# Altium schematic sheet CPU_.SchDoc
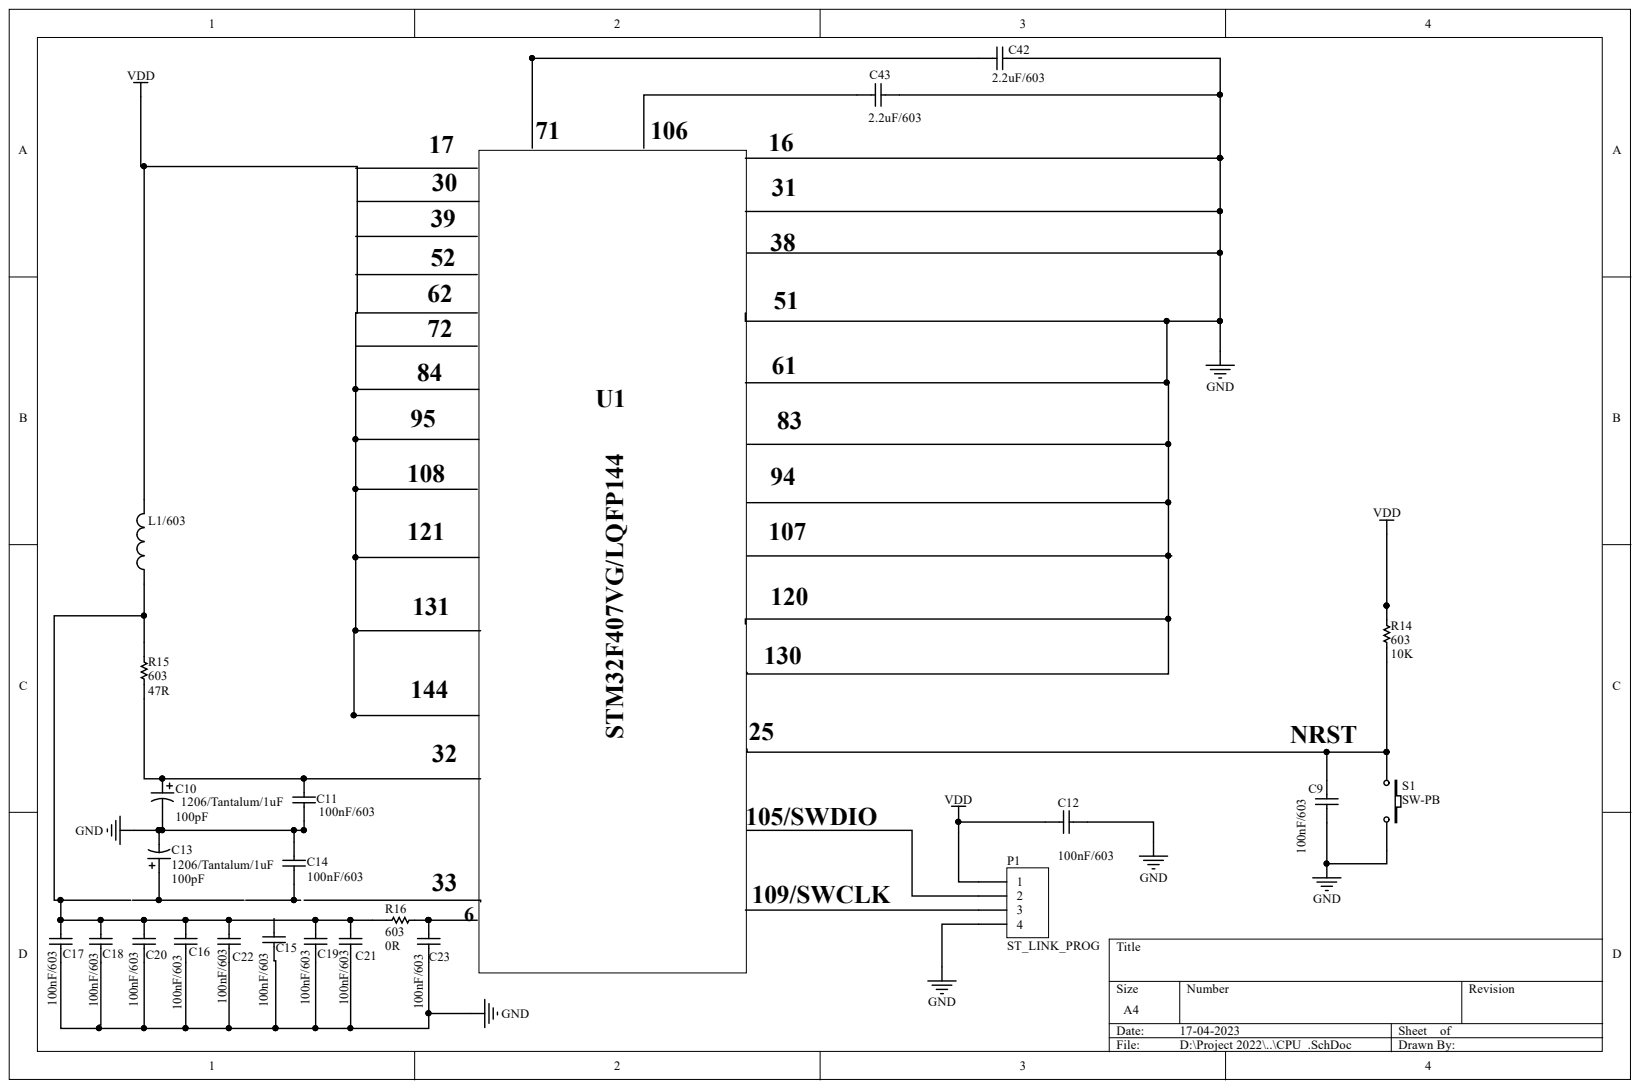
[diagram p0: CPU_.SchDoc]

## Parts
C9  value 100nF/603  [RAD-0.3]
C10  1206/Tantalum/1uF  value 100pF  [RB7.6-15]
C11  value 100nF/603  [RAD-0.3]
C12  value 100nF/603  [RAD-0.3]
C13  1206/Tantalum/1uF  value 100pF  [RB7.6-15]
C14  value 100nF/603  [RAD-0.3]
C15  value 100nF/603  [RAD-0.3]
C16  value 100nF/603  [RAD-0.3]
C17  value 100nF/603  [RAD-0.3]
C18  value 100nF/603  [RAD-0.3]
C19  value 100nF/603  [RAD-0.3]
C20  value 100nF/603  [RAD-0.3]
C21  value 100nF/603  [RAD-0.3]
C22  value 100nF/603  [RAD-0.3]
C23  value 100nF/603  [RAD-0.3]
C42  value 2.2uF/603  [RAD-0.3]
C43  value 2.2uF/603  [RAD-0.3]
L1/603  (no value)  [0402-A]
P1  ST_LINK_PROG  [HDR1X4]
R14  603  value 10K  [AXIAL-0.3]
R15  603  value 47R  [AXIAL-0.3]
R16  603  value 0R  [AXIAL-0.3]
S1  SW-PB  [SPST-2]

## Nets
GND: C9.1 C10.2 C11.1 C12.1 C13.2 C14.2 C15.1 C16.1 C17.1 C18.1 C19.1 C20.1 C21.1 C22.1 C23.1 C42.1 C43.1 P1.4 S1.2
VDD: C12.2 L1/603.2 P1.1 R14.2
NetC9_2: C9.2 R14.1 S1.1
NetC10_1: C10.1 C11.2 R15.1
NetC16_2: C16.2 C17.2 C18.2 C19.2 C20.2 C21.2 C22.2 L1/603.1 R15.2 R16.2
NetC23_2: C23.2 R16.1
N00002:

Not connected: C13 1; C14 1; C15 2; C42 2; C43 2; P1 2 3

## Library data of the parts
C9 C11 C12 C14-C23 C42 C43 = Cap: Capacitor; Component Kind: Standard; LatestRevisionDate: 17-Jul-2002; LatestRevisionNote: Re-released for DXP Platform.; Library Name: Miscellaneous Devices.IntLib; PackageDescription: Radial Cap, Thru-Hole; 2 Leads; 0.3 in Pin Spacing; PackageReference: RAD-0.3; Published: 8-Jun-2000; Signal Integrity: Cap; Simulation: CAP; Publisher: Altium Limited
C10 C13 = Cap Pol1: Polarized Capacitor (Radial); Component Kind: Standard; LatestRevisionDate: 17-Jul-2002; LatestRevisionNote: Re-released for DXP Platform.; Library Name: Miscellaneous Devices.IntLib; PackageDescription: Polarized Capacitor (Radial); 2 Leads; PackageReference: RB7.6-15; Published: 8-Jun-2000; Signal Integrity: Cap Pol; Simulation: CAP; Publisher: Altium Limited
L1/603 = Inductor: Code_IEC: 1005; Code_JEITA: 0402; Component Kind: Standard; Description: Inductor; LatestRevisionDate: 29-May-2009; LatestRevisionNote: IPC-7351 Footprint Added.; Library Name: Miscellaneous Devices.IntLib; PackageDescription: Chip Inductor; PackageReference: 0402-A; Published: 8-Jun-2000; Signal Integrity: Inductor; Simulation: INDUCTOR; Publisher: Altium Limited
P1 = Header 4: Header, 4-Pin; Component Kind: Standard; LatestRevisionDate: 17-Jul-2002; LatestRevisionNote: Re-released for DXP Platform.; Library Name: Miscellaneous Connectors.IntLib; Signal Integrity: Connector; Publisher: Altium Limited
R14 R15 = Res1: Resistor; Component Kind: Standard; LatestRevisionDate: 17-Jul-2002; LatestRevisionNote: Re-released for DXP Platform.; Library Name: Miscellaneous Devices.IntLib; PackageDescription: Axial Device, Thru-Hole; 2 Leads; 0.3 in Pin Spacing; PackageReference: AXIAL-0.3; Published: 8-Jun-2000; Signal Integrity: Res; Simulation: RESISTOR; Publisher: Altium Limited
R16 = Res1: Resistor; Value: 0R; Component Kind: Standard; LatestRevisionDate: 17-Jul-2002; LatestRevisionNote: Re-released for DXP Platform.; Library Name: Miscellaneous Devices.IntLib; PackageDescription: Axial Device, Thru-Hole; 2 Leads; 0.3 in Pin Spacing; PackageReference: AXIAL-0.3; Published: 8-Jun-2000; Signal Integrity: Res; Simulation: RESISTOR; Publisher: Altium Limited
S1 = SW-PB: Switch; Component Kind: Standard; LatestRevisionDate: 17-Jul-2002; LatestRevisionNote: Re-released for DXP Platform.; Library Name: Miscellaneous Devices.IntLib; PackageDescription: Switch; 2 Leads; PackageReference: SPST-2; Published: 24-Mar-1999; Publisher: Altium Limited
